# Altium schematic sheet Conductivity.SchDoc
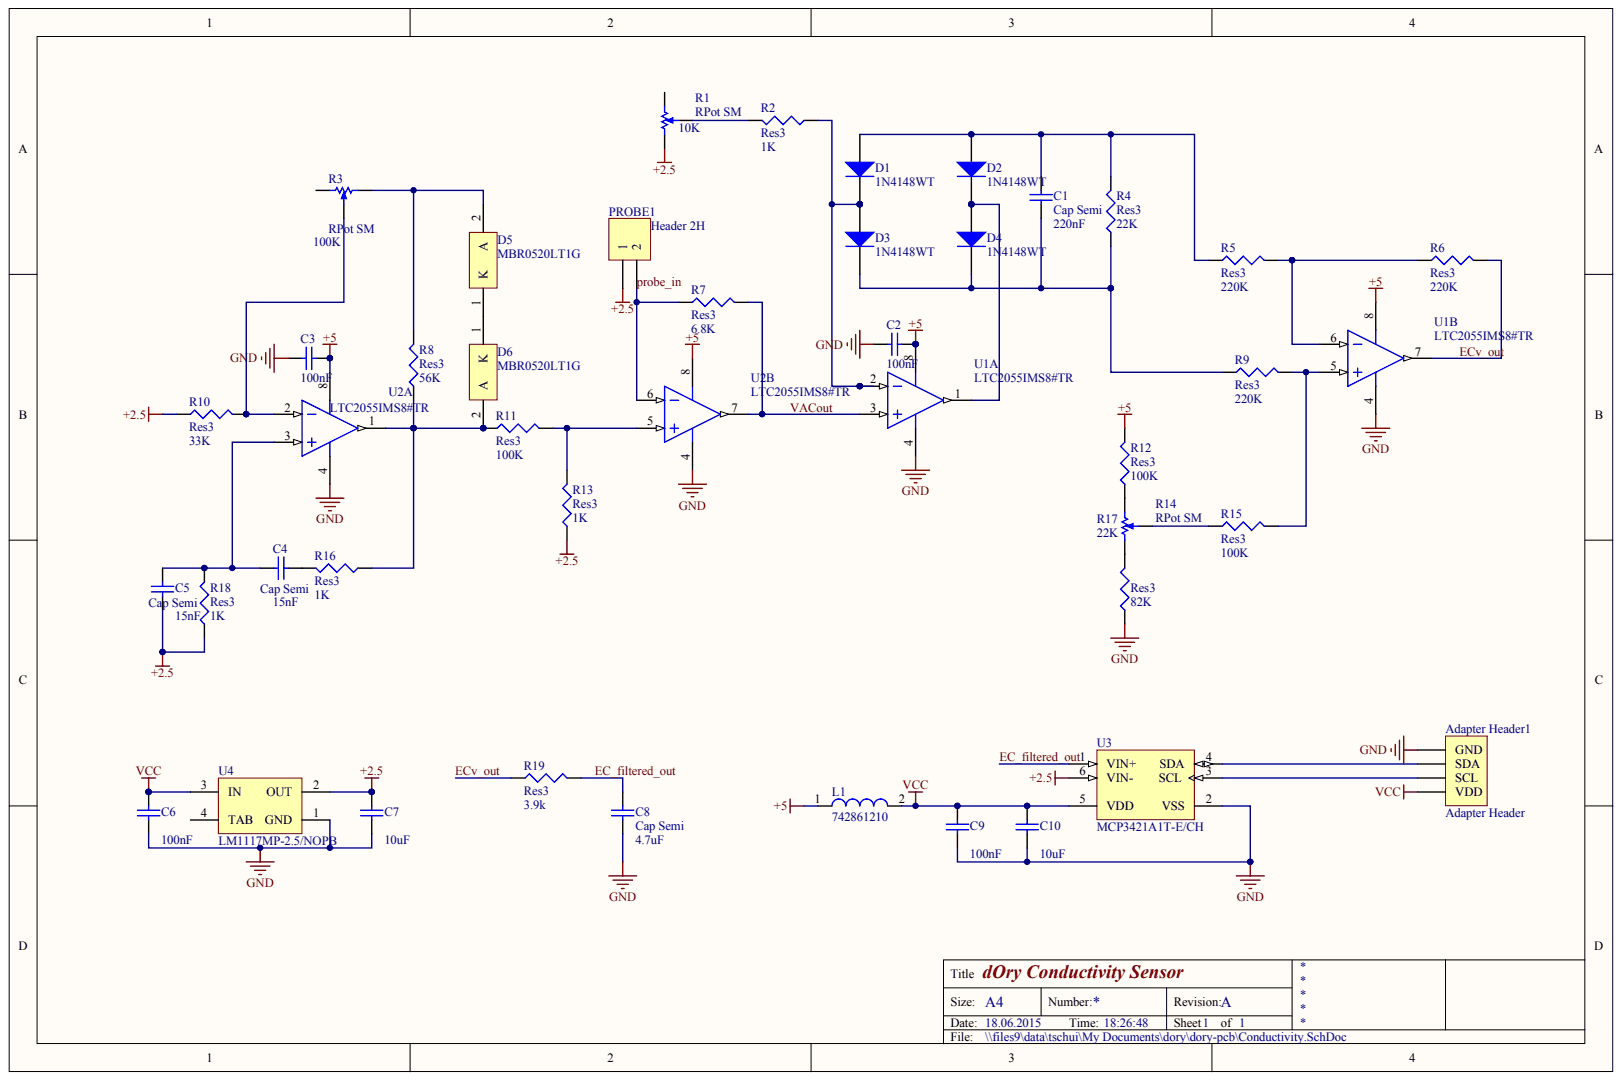
[diagram p0: Conductivity.SchDoc]

## Parts
Adapter_Header1  Adapter Header
C1  Cap Semi  value 220nF
C2  value 100nF  (hidden comment: Cap Semi)
C3  value 100nF  (hidden comment: Cap Semi)
C4  Cap Semi  value 15nF
C5  Cap Semi  value 15nF
C6  value 100nF  (hidden comment: Cap Semi)
C7  value 10uF  (hidden comment: Cap Semi)
C8  Cap Semi  value 4.7uF
C9  value 100nF  (hidden comment: Cap Semi)
C10  value 10uF  (hidden comment: Cap Semi)
D1  1N4148WT
D2  1N4148WT
D3  1N4148WT
D4  1N4148WT
D5  MBR0520LT1G
D6  MBR0520LT1G
L1  742861210
PROBE1  Header 2H
R1  RPot SM  value 10K
R2  Res3  value 1K
R3  RPot SM  value 100K
R4  Res3  value 22K
R5  Res3  value 220K
R6  Res3  value 220K
R7  Res3  value 6.8K
R8  Res3  value 56K
R9  Res3  value 220K
R10  Res3  value 33K
R11  Res3  value 100K
R12  Res3  value 100K
R13  Res3  value 1K
R14  RPot SM  value 22K
R15  Res3  value 100K
R16  Res3  value 1K
R17  Res3  value 82K
R18  Res3  value 1K
R19  Res3  value 3.9k
U1  LTC2055IMS8#TR  (parts A,B)
U2  LTC2055IMS8#TR  (parts A,B)
U3  MCP3421A1T-E/CH
U4  LM1117MP-2.5/NOPB

## Nets
+2.5: C5.1 C7.2 PROBE1.1 R1.CCW R10.1 R13.1 R18.1 U3.6(VIN-) U4.2(OUT)
+5: C2.1 C3.1 L1.1 R12.2 U1.8 U2.8
GND: Adapter_Header1.1(GND) C2.2 C3.2 C6.1 C7.1 C8.1 C9.1 C10.1 R17.1 U1.4 U2.4 U3.2(VSS) U4.1(GND)
VCC: Adapter_Header1.4(VDD) C6.2 C9.2 C10.2 L1.2 U3.5(VDD) U4.3(IN)
EC_FILTERED_OUT: C8.2 R19.2 U3.1(VIN+)
ECV_OUT: R6.2 R19.1 U1.7
PROBE_IN: PROBE1.2 R7.1 U2.6
VACOUT: R7.2 U1.3 U2.7
NetAdapter Header1_2: Adapter_Header1.2(SDA) U3.4(SDA)
NetAdapter Header1_3: Adapter_Header1.3(SCL) U3.3(SCL)
NetC1_1: C1.1 D3.1 D4.1 R4.1 R9.1
NetC1_2: C1.2 D1.2 D2.2 R4.2 R5.1
NetC4_1: C4.1 C5.2 R18.2 U2.3
NetC4_2: C4.2 R16.2
NetD1_1: D1.1 D3.2 R2.1 U1.2
NetD2_1: D2.1 D4.2 U1.1
NetD5_1: D5.1 D6.1
NetD5_2: D5.2 R3.CW R8.2
NetD6_2: D6.2 R8.1 R11.1 R16.1 U2.1
NetR1_W: R1.W R2.2
NetR3_W: R3.W R10.2 U2.2
NetR5_2: R5.2 R6.1 U1.6
NetR9_2: R9.2 R15.2 U1.5
NetR11_2: R11.2 R13.2 U2.5
NetR12_1: R12.1 R14.CW
NetR14_CCW: R14.CCW R17.2
NetR14_W: R14.W R15.1

Not connected: R1 CW; R3 CCW; U4 4(TAB)

## Library data of the parts
D1-D4 = (no symbol name): Manufacturer: Fairchild Semiconductor; IR (VR: 75 V)=5 uA Max.; VF: 1 V Max.; BVR (IR: 5 uA)=75 V Min.; CO: 4 pF Max.; DatasheetVersion: Rev. A2; ComponentLink1Description: Manufacturer URL; PackageDescription: 2-Pin Surface Mount Device, Body 1.2 x 0.8 mm, Height 0.7 mm; ComponentLink2URL: http://www.fairchildsemi.com/ds/1N/1N4148WT.pdf; ComponentLink2Description: Datasheet; PartNumber: 1N4148WT; ComponentLink1URL: http://www.fairchildsemi.com/; Temperature Range: -55 to 150 degC; Mounting Technology: Surface Mount; PackageReference: SOD-523F-2; RoHS: TRUE
D5 D6 = (no symbol name): Manufacturer: On Semiconductor; IFSM Max (A): 5.5; Configuration: Single; Packing: Tape and Reel; ComponentLink2Description: Datasheet; RoHS: TRUE; PartNumber: MBR0520LT1G; PackageVersion: Rev. G, 10/2009; DatasheetVersion: Rev. 6; PackageReference: SOD-123-2-425-04; ComponentLink2URL: http://www.onsemi.cn/pub_link/Collateral/MBR0520LT1-D.PDF; ComponentLink3Description: Package Specification; ComponentLink1URL: http://www.onsemi.com/; ComponentLink3URL: http://www.onsemi.com/pub_link/Collateral/425-04.PDF; ComponentLink1Description: Manufacturer URL; PackageDescription: 2-Pin SOD, Body 1.6 x 2.69 mm; Mounting Technology: Surface Mount
L1 = (no symbol name): Impedance: 1000 Ohm; PackageDescription: Chip Inductor, 2-Leads, Body 1.6 x 0.8 mm; PackageReference: SMD-0603; DatasheetVersion: ver 2, Aug-2009; ComponentLink2Description: Datasheet; ComponentLink1Description: Manufacturer Link; PackageVersion: 2009; ComponentLink2URL: http://www.we-online.de/katalog/media/pdf/742861210.pdf; ComponentLink1URL: http://www.we-online.com
U1 U2 = (no symbol name): Manufacturer: Linear Technology; GBW (MHz) Typical: 0.5; Vs Min (V): 2.7; Channels (#): 2; Packing: Reel; Is (mA) Typical: 0.135; Vs Max (V): 6; Vos (mV) Typical: 0.0005; PackageDescription: 8-Lead Plastic Small Outline, Body 3.9 x 4.9 mm, Pitch 1.27 mm; Type: ZD; ComponentLink2URL: http://cds.linear.com/docs/en/datasheet/20545fc.pdf; PartNumber: LTC2055IMS8#TR; RevisionNote: URLs updated; ComponentLink2Description: Datasheet; DatasheetVersion: Rev. C; ComponentLink3URL: http://cds.linear.com/docs/en/packaging/05081610_G_SO8.pdf; ComponentLink3Description: Package Specification; ComponentLink1URL: http://www.linear.com/; PackageReference: LT-MS8-8; PackageVersion: Rev G; ComponentLink1Description: Manufacturer URL; Mounting Technology: Surface Mount; SR (V/us) Typical: 0.5; Linear Technology Part Number: LTC2055
U4 = (no symbol name): Manufacturer: National Semiconductor; PackageTopMarking: XYTT*N13A; CompType: LDO IC; PowerWise: N; ComponentLink2Description: LM1117 Web Page; ComponentLink2URL: http://www.national.com/pf/LM/LM1117.html; ComponentLink1URL: http://www.national.com/ds/LM/LM1117.pdf; PackageVersion: revB, Apr-2003; PinCount: 4; PackageReference: MP04A; RoHS: Y; DatasheetVersion: Apr-2006; PackageDescription: Molded SOT-223, 6.50 x 3.56 x 1.6mm Body, 4 Lead, 2.3mm Pitch; Code_JEDEC: TO-261-AA; ComponentLink3Description: MP04A Package Page; RevisionNote: Component URLs added; ComponentLink3URL: http://www.national.com/packaging/folders/mp04a.html; Code_IPC: SOT223-4; ComponentLink1Description: LM1117 Datasheet
Adapter_Header1 C1-C10 PROBE1 R1-R19 U3 = (no symbol name)
  Adapter_Header1 PROBE1: LatestRevisionDate: 17-Jul-2002; LatestRevisionNote: Re-released for DXP Platform.
  C1-C10: Published: 8-Jun-2000; LatestRevisionDate: 29-May-2009; LatestRevisionNote: IPC-7351 Footprint Added.; PackageReference: C1206; PackageDescription: Chip Capacitor
  R1 R3: Published: 17-Dec-2003; DatasheetVersion: Oct-2003; PackageReference: POT4MM-2; PackageDescription: Square Trimming Potentiometer; ComponentLink1Description: Manufacturer Link; ComponentLink1URL: http://bourns.com/2/search.html; : *
  R2 R4-R13 R15-R19: Published: 8-Jun-2000; LatestRevisionDate: 29-May-2009; LatestRevisionNote: IPC-7351 Footprint Added.; PackageReference: J1-0603; PackageDescription: Chip Resistor
  R14: Published: 17-Dec-2003; DatasheetVersion: Oct-2003; PackageReference: POT4MM-2; PackageDescription: Square Trimming Potentiometer; ComponentLink1Description: Manufacturer Link; ComponentLink1URL: http://bourns.com/2/search.html
  U3: ComponentLink1URL: http://www.microchip.com/; ComponentLink3Description: Package Specification; PackageDescription: 6-Lead Plastic Small Outline Transistor (CH) [SOT-23]; ComponentLink2URL: http://ww1.microchip.com/downloads/en/DeviceDoc/22003e.pdf; ComponentLink2Description: Datasheet; PackageReference: SOT-23-CH6; PackageVersion: revBB, Aug-2009; RevisionNote: URLs added.; Code_IPC: SOT26A-6; ComponentLink1Description: Manufacturer URL; DatasheetVersion: revE, Aug-2009; ComponentLink3URL: http://www.microchip.com/stellent/groups/techpub_sg/documents/packagingspec/en012702.pdf
  Adapter_Header1 C1-C10 PROBE1 R1-R19: Publisher: Altium Limited
